# Altium schematic sheet 02_ATK_DLRK3506J_Core_WIFI_BT.SchDoc
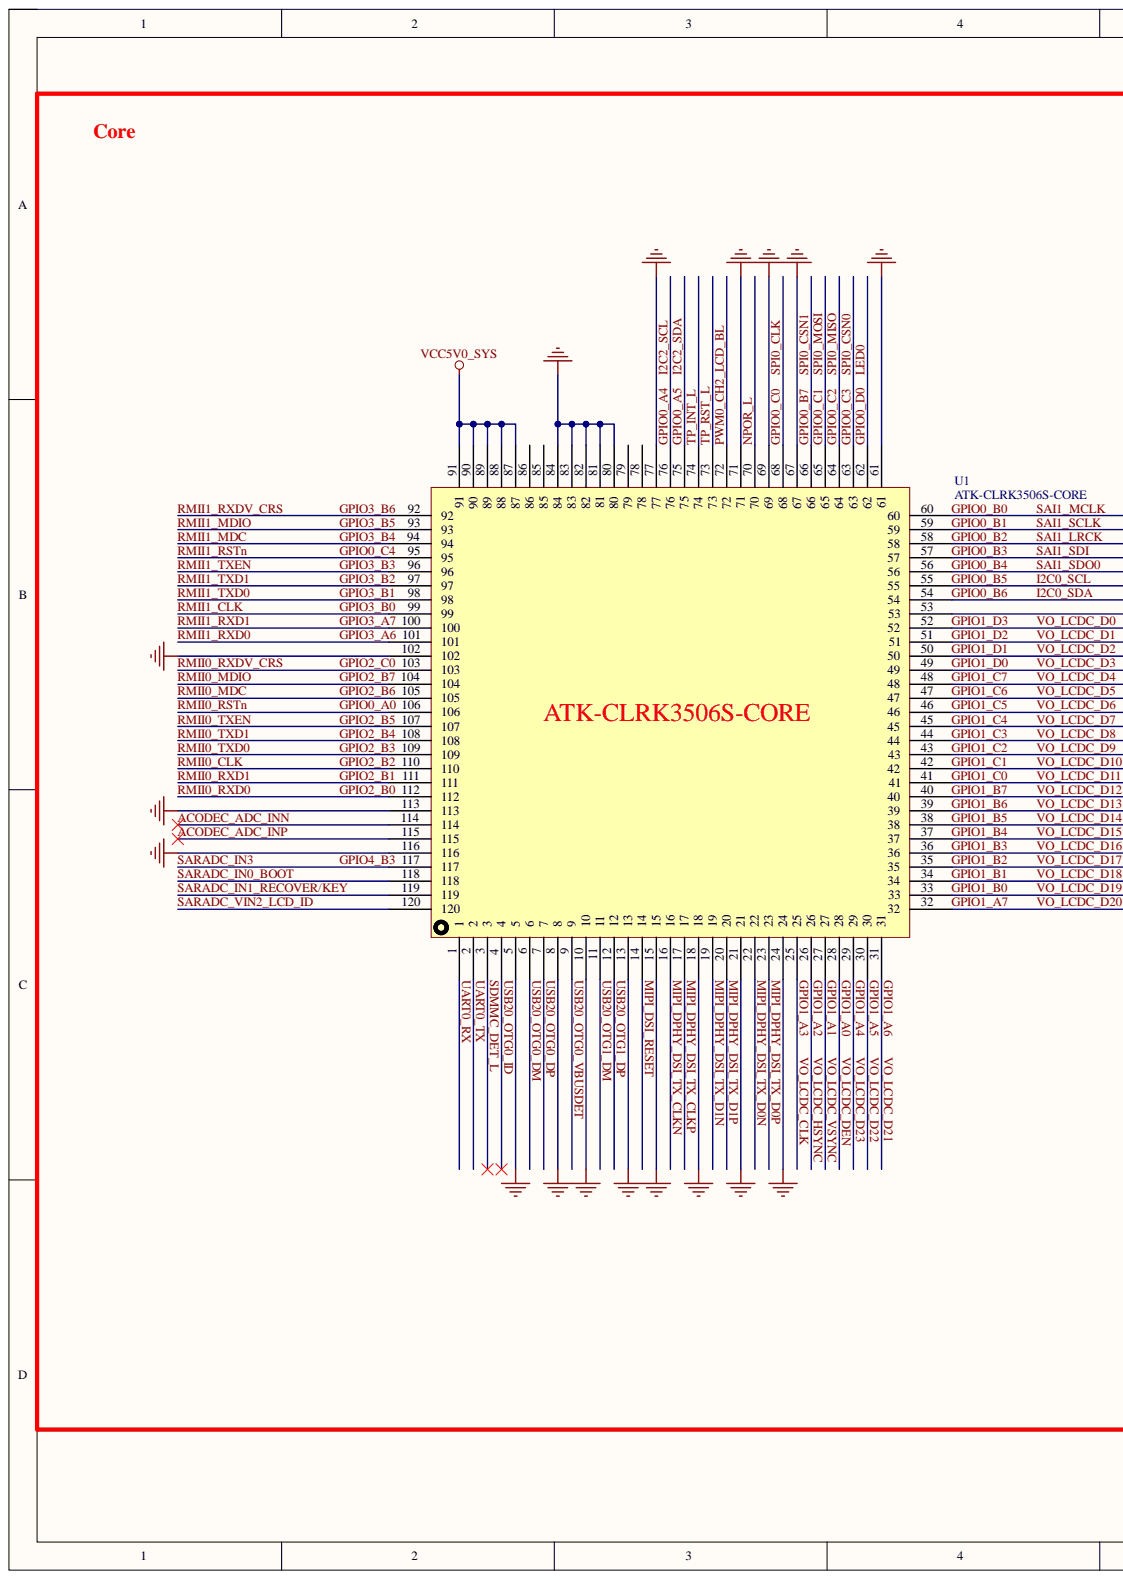
[diagram p0: 02_ATK_DLRK3506J_Core_WIFI_BT.SchDoc, left]
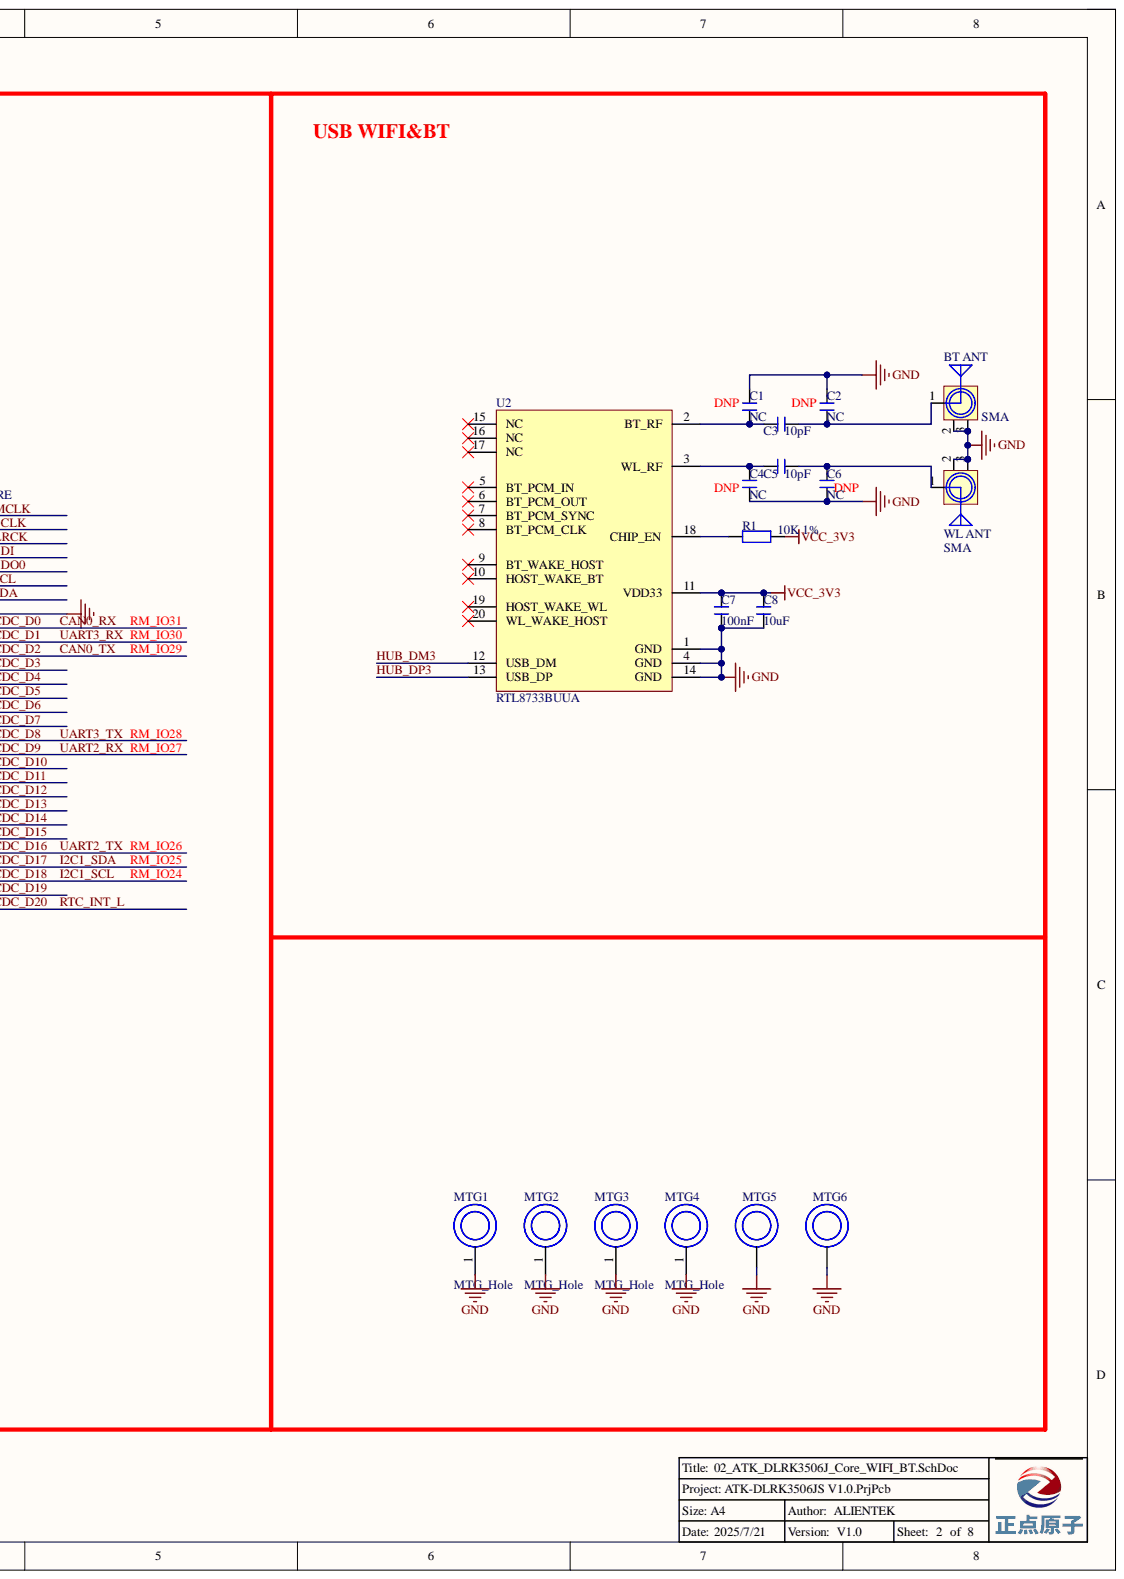
[diagram p1: 02_ATK_DLRK3506J_Core_WIFI_BT.SchDoc, right]

## Parts
BT_ANT  SMA  [SMA-KWE]
C1  NC  [C 0402]
C2  NC  [C 0402]
C3  10pF  [C 0402]
C4  NC  [C 0402]
C5  10pF  [C 0402]
C6  NC  [C 0402]
C7  100nF  [C 0402]
C8  10uF  [C 0402]
MTG1  MTG_Hole  [M3-6ET]
MTG2  MTG_Hole  [M3-6ET]
MTG3  MTG_Hole  [M3-6ET]
MTG4  MTG_Hole  [M3-6ET]
MTG5  螺母(M3*6,贴片螺母柱,白色,SMTSO-M3-6ET)  [铜柱(M2.5,贴片铜柱,黄铜,2.9*2.2+4.0*4.3mm)]  (value not drawn)
MTG6  螺母(M3*6,贴片螺母柱,白色,SMTSO-M3-6ET)  [铜柱(M2.5,贴片铜柱,黄铜,2.9*2.2+4.0*4.3mm)]  (value not drawn)
R1  10K 1%  [R 0402]
U1  ATK-CLRK3506S-CORE  [ATK-CLRK3506S-CORE-TEST]
U2  RTL8733BUUA  [RTL8733BUBUA]
WL_ANT  SMA  [SMA-KWE]

## Nets
GND: BT_ANT.2 BT_ANT.3 C1.1 C2.1 C4.2 C6.2 C7.2 C8.2 MTG1.1 MTG2.1 MTG3.1 MTG4.1 MTG5.0 MTG6.0 U1.5 U1.8 U1.10 U1.13 U1.15 U1.18 U1.21 U1.24 U1.53 U1.61 U1.67 U1.69 U1.71 U1.77 U1.80 U1.81 U1.82 U1.83 U1.84 U1.102 U1.113 U1.116 U2.1(GND) U2.4(GND) U2.14(GND) WL_ANT.2 WL_ANT.3
VCC5V0_SYS: U1.87 U1.88 U1.89 U1.90 U1.91
VCC_3V3: C7.1 C8.1 R1.2 U2.11(VDD33)
ACODEC_ADC_INN: U1.114
ACODEC_ADC_INP: U1.115
CAN0_RX: U1.52
CAN0_TX: U1.50
GPIO0_A0: U1.106
GPIO0_A4: U1.76
GPIO0_A5: U1.75
GPIO0_B0: U1.60
GPIO0_B1: U1.59
GPIO0_B2: U1.58
GPIO0_B3: U1.57
GPIO0_B4: U1.56
GPIO0_B5: U1.55
GPIO0_B6: U1.54
GPIO0_B7: U1.66
GPIO0_C0: U1.68
GPIO0_C1: U1.65
GPIO0_C2: U1.64
GPIO0_C3: U1.63
GPIO0_C4: U1.95
GPIO0_D0: U1.62
GPIO1_A0: U1.28
GPIO1_A1: U1.27
GPIO1_A2: U1.26
GPIO1_A3: U1.25
GPIO1_A4: U1.29
GPIO1_A5: U1.30
GPIO1_A6: U1.31
GPIO1_A7: U1.32
GPIO1_B0: U1.33
GPIO1_B1: U1.34
GPIO1_B2: U1.35
GPIO1_B3: U1.36
GPIO1_B4: U1.37
GPIO1_B5: U1.38
GPIO1_B6: U1.39
GPIO1_B7: U1.40
GPIO1_C0: U1.41
GPIO1_C1: U1.42
GPIO1_C2: U1.43
GPIO1_C3: U1.44
GPIO1_C4: U1.45
GPIO1_C5: U1.46
GPIO1_C6: U1.47
GPIO1_C7: U1.48
GPIO1_D0: U1.49
GPIO1_D2: U1.51
GPIO2_B0: U1.112
GPIO2_B1: U1.111
GPIO2_B2: U1.110
GPIO2_B3: U1.109
GPIO2_B4: U1.108
GPIO2_B5: U1.107
GPIO2_B6: U1.105
GPIO2_B7: U1.104
GPIO2_C0: U1.103
GPIO3_A6: U1.101
GPIO3_A7: U1.100
GPIO3_B0: U1.99
GPIO3_B1: U1.98
GPIO3_B2: U1.97
GPIO3_B3: U1.96
GPIO3_B4: U1.94
GPIO3_B5: U1.93
GPIO3_B6: U1.92
GPIO4_B3: U1.117
HUB_DM3: U2.12(USB_DM)
HUB_DP3: U2.13(USB_DP)
MIPI_DPHY_DSI_TX_CLKN: U1.16
MIPI_DPHY_DSI_TX_CLKP: U1.17
MIPI_DPHY_DSI_TX_D0N: U1.22
MIPI_DPHY_DSI_TX_D0P: U1.23
MIPI_DPHY_DSI_TX_D1N: U1.19
MIPI_DPHY_DSI_TX_D1P: U1.20
MIPI_DSI_RESET: U1.14
NPOR_L: U1.70
PWM0_CH2_LCD_BL: U1.72
SARADC_IN0_BOOT: U1.118
SARADC_IN1_RECOVER/KEY: U1.119
SARADC_VIN2_LCD_ID: U1.120
SDMMC_DET_L: U1.3
TP_INT_L: U1.74
TP_RST_L: U1.73
UART0_RX: U1.1
UART0_TX: U1.2
USB20_OTG0_DM: U1.6
USB20_OTG0_DP: U1.7
USB20_OTG0_ID: U1.4
USB20_OTG0_VBUSDET: U1.9
USB20_OTG1_DM: U1.11
USB20_OTG1_DP: U1.12
NetBT ANT_1: BT_ANT.1 C2.2 C3.2
NetC1_2: C1.2 C3.1 U2.2(BT_RF)
NetC4_1: C4.1 C5.1 U2.3(WL_RF)
NetC5_2: C5.2 C6.1 WL_ANT.1
NetR1_1: R1.1 U2.18(CHIP_EN)

Not connected: U1 78 79 85 86; U2 5(BT_PCM_IN) 6(BT_PCM_OUT) 7(BT_PCM_SYNC) 8(BT_PCM_CLK) 9(BT_WAKE_HOST) 10(HOST_WAKE_BT) 15(NC) 16(NC) 17(NC) 19(HOST_WAKE_WL) 20(WL_WAKE_HOST)

## Library data of the parts
BT_ANT WL_ANT = SMA: SMA-2.4G射频天线接口; 版权归属: 正点原子; BOM名称: SMA-2.4G射频天线接口; Component Kind: Standard; Library Name: 接口类.SchLib; 学习资源: www.openedv.com
C1-C8 = C: 无极性电容; BOM名称: 无极性贴片电容; 版权归属: 正点原子; Component Kind: Standard; Library Name: 正点原子封装库V1.0.IntLib; 学习资源: www.openedv.com
MTG1-MTG4 = MTG_Hole: 螺丝孔; Component Kind: Standard; Library Name: 正点原子封装库V1.0.IntLib
MTG5 MTG6 = MTG_Hole: 301020001; Component Kind: Standard; Library Name: PGL22G_V1.0.SCHLIB
R1 = R: 贴片电阻; BOM名称: 电阻; 版权归属: 正点原子; Component Kind: Standard; Library Name: 电阻-电容-电感.SchLib; 学习资源: www.openedv.com
U1 = ATK-CLRK3506S-CORE: Component Kind: Standard; Library Name: ATK-CLT113IS V1.0.SCHLIB
U2 = RTL8733BUUA: Component Kind: Standard; Library Name: ZZK_COMPONENT.IntLib
